# Altium schematic sheet Input power.SchDoc("Input power")
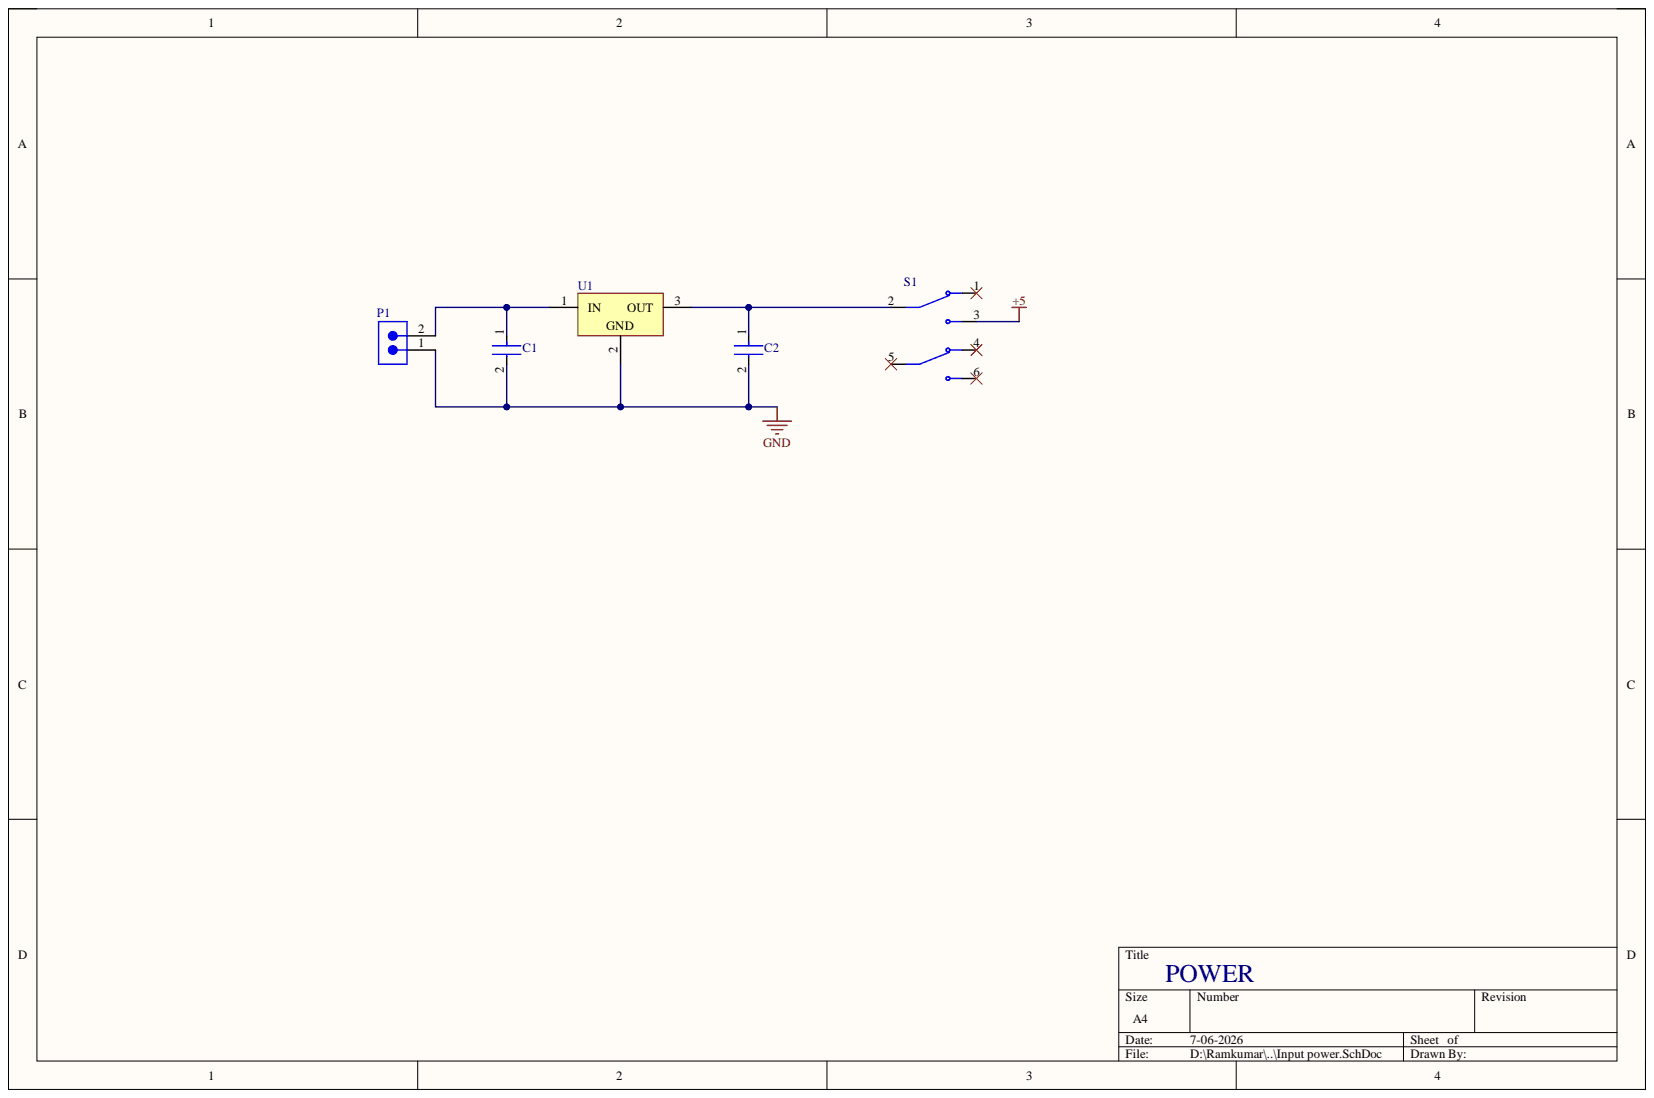
[diagram p0: Input power.SchDoc]

## Parts
C1  D222K33Y5PL6UJ5R  [FP-D222K33Y5PL6UJ5R-MFG]  (value not drawn)
C2  D222K33Y5PL6UJ5R  [FP-D222K33Y5PL6UJ5R-MFG]  (value not drawn)
P1  691311500002  [691311500002]  (value not drawn)
S1  TL2230OAF140  [SW_TL2230OAF140]  (value not drawn)
U1  L7805CP  [TO220FP]  (value not drawn)

## Nets
+5: S1.3
GND: C1.2 C2.2 P1.1 U1.2
NetC1_1: C1.1 P1.2 U1.1
NetC2_1: C2.1 S1.2 U1.3

Not connected: S1 1 4 5 6

## Library data of the parts
C1 C2 = CMP-02417-000074-1: CAP CER 2200PF 500V Y5P RADIAL; Capacitance: 2.2nF; Lead Diameter: 0.6mm; Lead/Base Style: Kinked; Tolerance: 10%; Case/Package: Radial; Component Kind: Standard; Construction: RADIAL; Contact Plating: Tin; Depth: 4mm; Dielectric: Y5P; Lead Pitch: 5mm; Library Name: Altium Content Vault; Max Operating Temperature: 85°C; Min Operating Temperature: -30°C; Mount: Through Hole; Package Quantity: 2000; Packaging: Tape and Reel; Radiation Hardening: No; Resistor Type: Ceramic; RoHS Compliant: Yes; Series: D; Termination: Radial; Voltage Rating: 500V
P1 = CMP-1502-02123-2: NexarPartId: 8917741; Current: 20A; Gender: Male; Number of Contacts: 2; Number of Levels: 1; Pitch: 5.08mm; Voltage: 300V; Color: Green; Component Kind: Standard; Contact Material: Brass; Contact Plating: Tin; Height: 12mm; Lead Pitch: 5.08mm; Length: 8.5mm; Library Name: Altium Content Vault; Mount: Through Hole; Number of Pins: 2; Number of Positions Per Level: 2; Number of Rows: 1; Orientation: Vertical; Packaging: Box; REACH SVHC: Unknown; RoHS: Compliant; Termination: Solder; Width: 10.16mm
S1 = TL2230OAF140: Pushbutton Switch DPDT Standard Through Hole; MF: E-Switch; Check_prices: https://www.snapeda.com/parts/TL2230OAF140/E-Switch/view-part/?ref=eda; Component Kind: Standard; Library Name: TL2230OAF140.IntLib; MP: TL2230OAF140; Package: None; SnapEDA_Link: https://www.snapeda.com/parts/TL2230OAF140/E-Switch/view-part/?ref=snap
U1 = CMP-0244-00474-1: Positive Voltage Regulator, 5V, 3-Pin TO-220FP; Component Kind: Standard; ComponentLink1Description: Manufacturer URL; ComponentLink1URL: http://www.st.com; ComponentLink2Description: Datasheet; ComponentLink2URL: http://www.st.com/internet/com/TECHNICAL_RESOURCES/TECHNICAL_LITERATURE/DATASHEET/CD00000444.pdf; DatasheetVersion: 16/11/2011; Library Name: Altium Content Vault; PackageDescription: TO, Thru-Hole, Vertical, Heatsink Mounted; 3 In-Line Leads; Pitch 2.54 mm; PackageReference: TO220FP; PackageVersion: 2011
